# Altium schematic sheet PV_BMS_IO.SchDoc
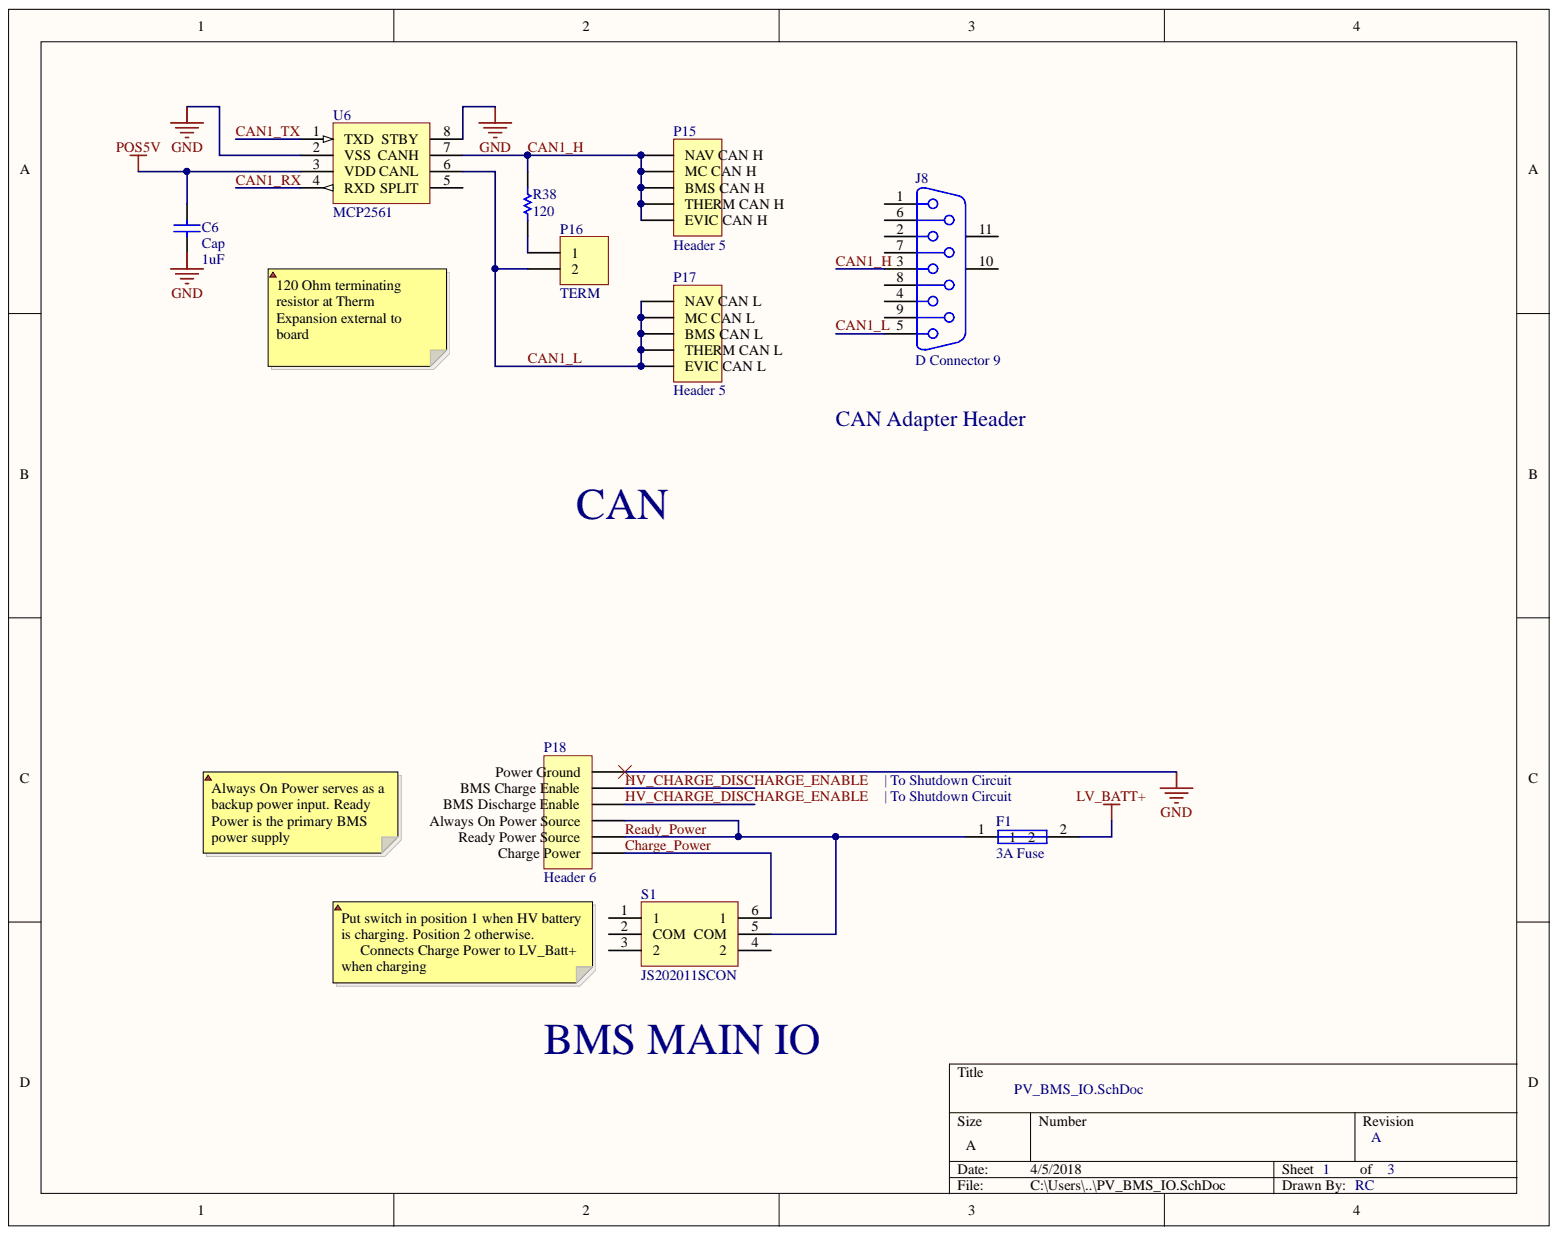
[diagram p0: PV_BMS_IO.SchDoc]

## Parts
C6  Cap  value 1uF  [1206]
F1  3A Fuse  [1A FUSE HOLDER]
J8  D Connector 9  [DSUB1.385-2H9]
P15  Header 5  [5POS TERM BLOCK]
P16  TERM  [HDR1X2]
P17  Header 5  [5POS TERM BLOCK]
P18  Header 6  [6POS TERM BLOCK]
R38  value 120  [1206]  (hidden comment: Res1)
S1  JS202011SCON  [JS202011SCON]
U6  MCP2561  [8-SOIC]

## Nets
GND: C6.1 P18.6 U6.2(VSS) U6.8(STBY)
LV_BATT+: F1.2
POS5V: C6.2 U6.3(VDD)
CAN1_H: J8.3 P15.1(NAV) P15.2(MC) P15.3(BMS) P15.4 P15.5(EVIC) R38.2 U6.7(CANH)
CAN1_L: J8.5 P16.2 P17.1(NAV) P17.2(MC) P17.3(BMS) P17.4 P17.5(EVIC) U6.6(CANL)
CAN1_RX: U6.4(RXD)
CAN1_TX: U6.1(TXD)
Charge_Power: P18.1(Power) S1.6
HV_CHARGE_DISCHARGE_ENABLE: P18.4 P18.5
Ready_Power: F1.1 P18.2 P18.3 S1.5(COM)
NetJ8_2: J8.2
NetP16_1: P16.1 R38.1

Not connected: J8 1 4 6 7 8 9 10 11; S1 1 2(COM) 3 4; U6 5(SPLIT)

## Library data of the parts
C6 = Cap: Capacitor; Component Kind: Standard; LatestRevisionDate: 17-Jul-2002; LatestRevisionNote: Re-released for DXP Platform.; Library Name: Miscellaneous Devices.IntLib; PackageDescription: Radial Cap, Thru-Hole; 2 Leads; 0.3 in Pin Spacing; PackageReference: RAD-0.3; Published: 8-Jun-2000; Signal Integrity: Cap; Simulation: CAP; Publisher: Altium Limited
F1 = Fuse 1: Fuse; Component Kind: Standard; ComponentLink1Description: Digikey; ComponentLink1URL: F1226CT-ND; LatestRevisionDate: 17-Jul-2002; LatestRevisionNote: Re-released for DXP Platform.; Library Name: Miscellaneous Devices.IntLib; PackageDescription: Thru-Hole; 2 Leads; PackageReference: PIN-W2/E2.8; Published: 8-Jun-2000; Simulation: FUSE; Publisher: Altium Limited
J8 = D Connector 9: Receptacle Assembly, 9 Position, Right Angle; PackageDocument: O; Positions: 9; Component Kind: Standard; DatasheetDocument: 1-Sep-1999; Gender: Receptacle; LatestRevisionDate: 17-Jul-2002; LatestRevisionNote: Re-released for DXP Platform.; Library Name: Miscellaneous Connectors.IntLib; Orientation: Right Angle; PackageReference: 788750; Published: 15-Jun-2001; Signal Integrity: Connector; Publisher: Altium Limited
P15 P17 = Header 5: Header, 5-Pin; Component Kind: Standard; LatestRevisionDate: 17-Jul-2002; LatestRevisionNote: Re-released for DXP Platform.; Library Name: Miscellaneous Connectors.IntLib; Signal Integrity: Connector; Publisher: Altium Limited
P16 = Header 2: Header, 2-Pin; Component Kind: Standard; LatestRevisionDate: 17-Jul-2002; LatestRevisionNote: Re-released for DXP Platform.; Library Name: Miscellaneous Connectors.IntLib; Signal Integrity: Connector; Publisher: Altium Limited
P18 = Header 6: Header, 6-Pin; Component Kind: Standard; LatestRevisionDate: 17-Jul-2002; LatestRevisionNote: Re-released for DXP Platform.; Library Name: Miscellaneous Connectors.IntLib; Signal Integrity: Connector; Publisher: Altium Limited
R38 = Res1: Resistor; Component Kind: Standard; LatestRevisionDate: 17-Jul-2002; LatestRevisionNote: Re-released for DXP Platform.; Library Name: Miscellaneous Devices.IntLib; PackageDescription: Axial Device, Thru-Hole; 2 Leads; 0.3 in Pin Spacing; PackageReference: AXIAL-0.3; Published: 8-Jun-2000; Signal Integrity: Res; Simulation: RESISTOR; Publisher: Altium Limited
S1 = JS202011SCON: DPST Switch; Component Kind: Standard; ComponentLink1Description: Digikey; ComponentLink1URL: https://www.digikey.com/product-detail/en/c-k/JS202011SCQN/401-2002-1-ND/1640098; Library Name: ic.SchLib
U6 = MCP2561: CAN Transciever; Component Kind: Standard; ComponentLink1Description: Part; ComponentLink1URL: https://www.digikey.com/product-detail/en/microchip-technology/MCP2561-E-SN/MCP2561-E-SN-ND/4079961; ComponentLink2Description: Datasheet; ComponentLink2URL: http://ww1.microchip.com/downloads/en/DeviceDoc/20005167C.pdf; Library Name: ic.SchLib
